# Altium schematic sheet SmartPKMJIG.SchDoc
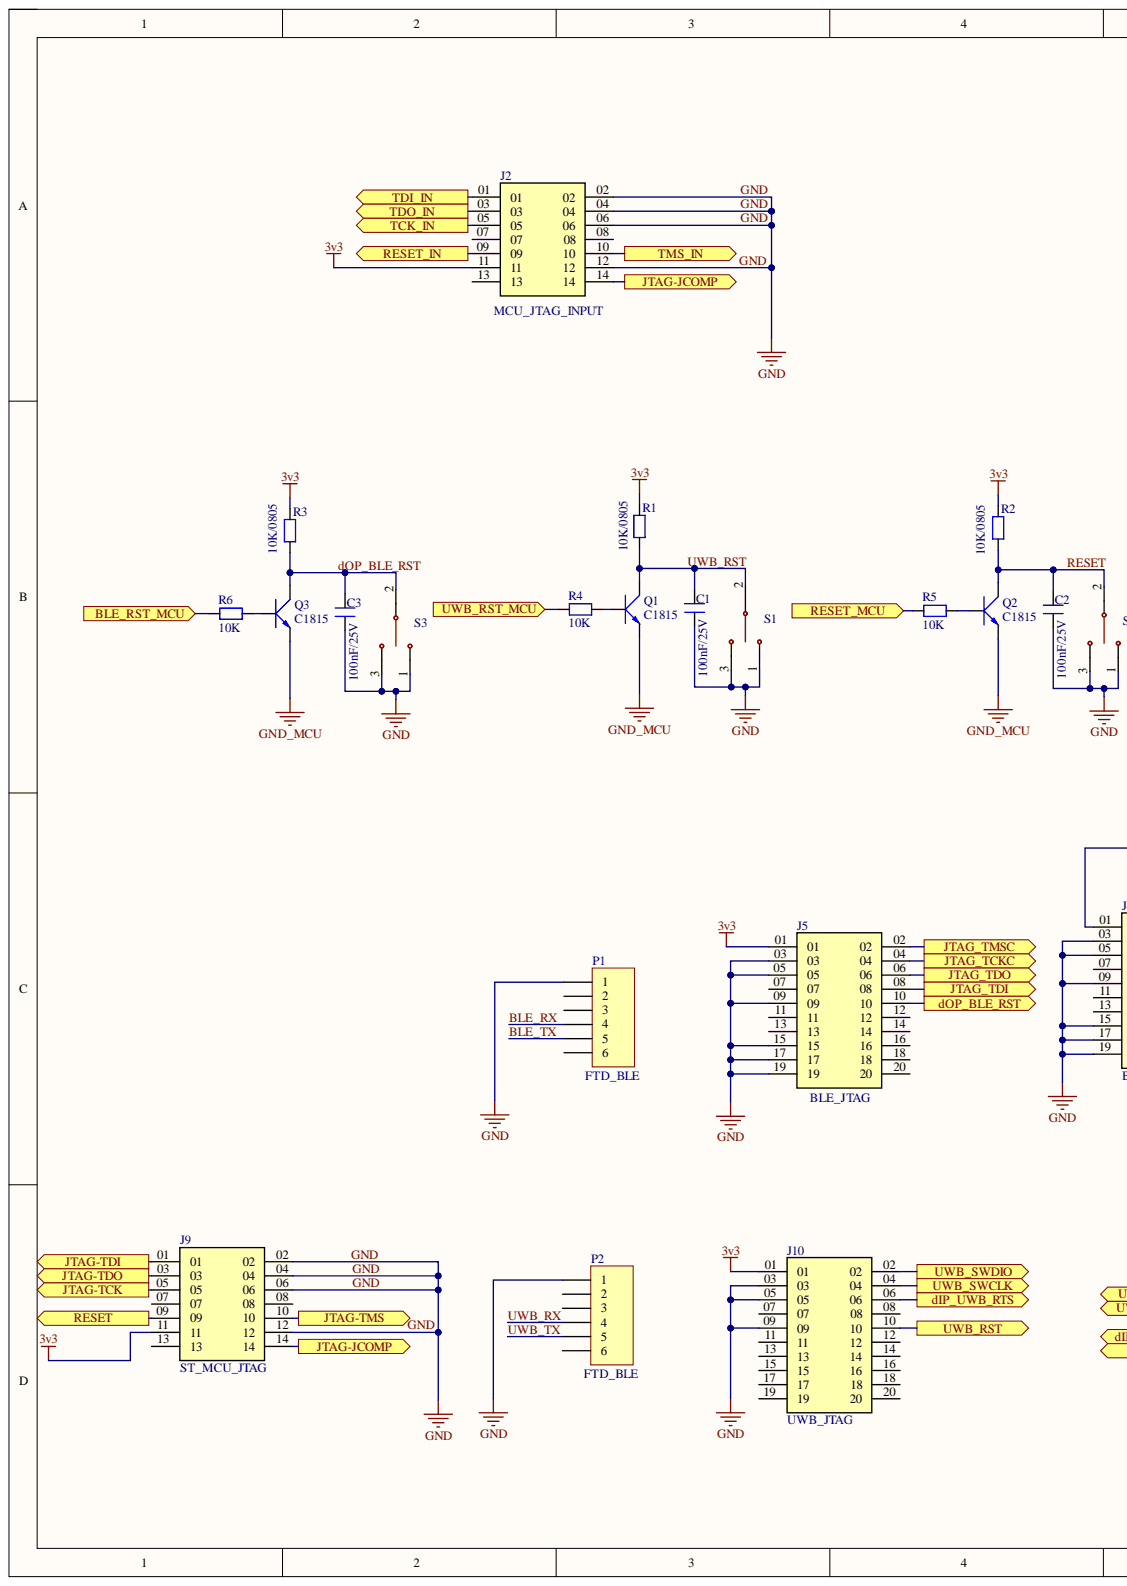
[diagram p0: SmartPKMJIG.SchDoc, left]
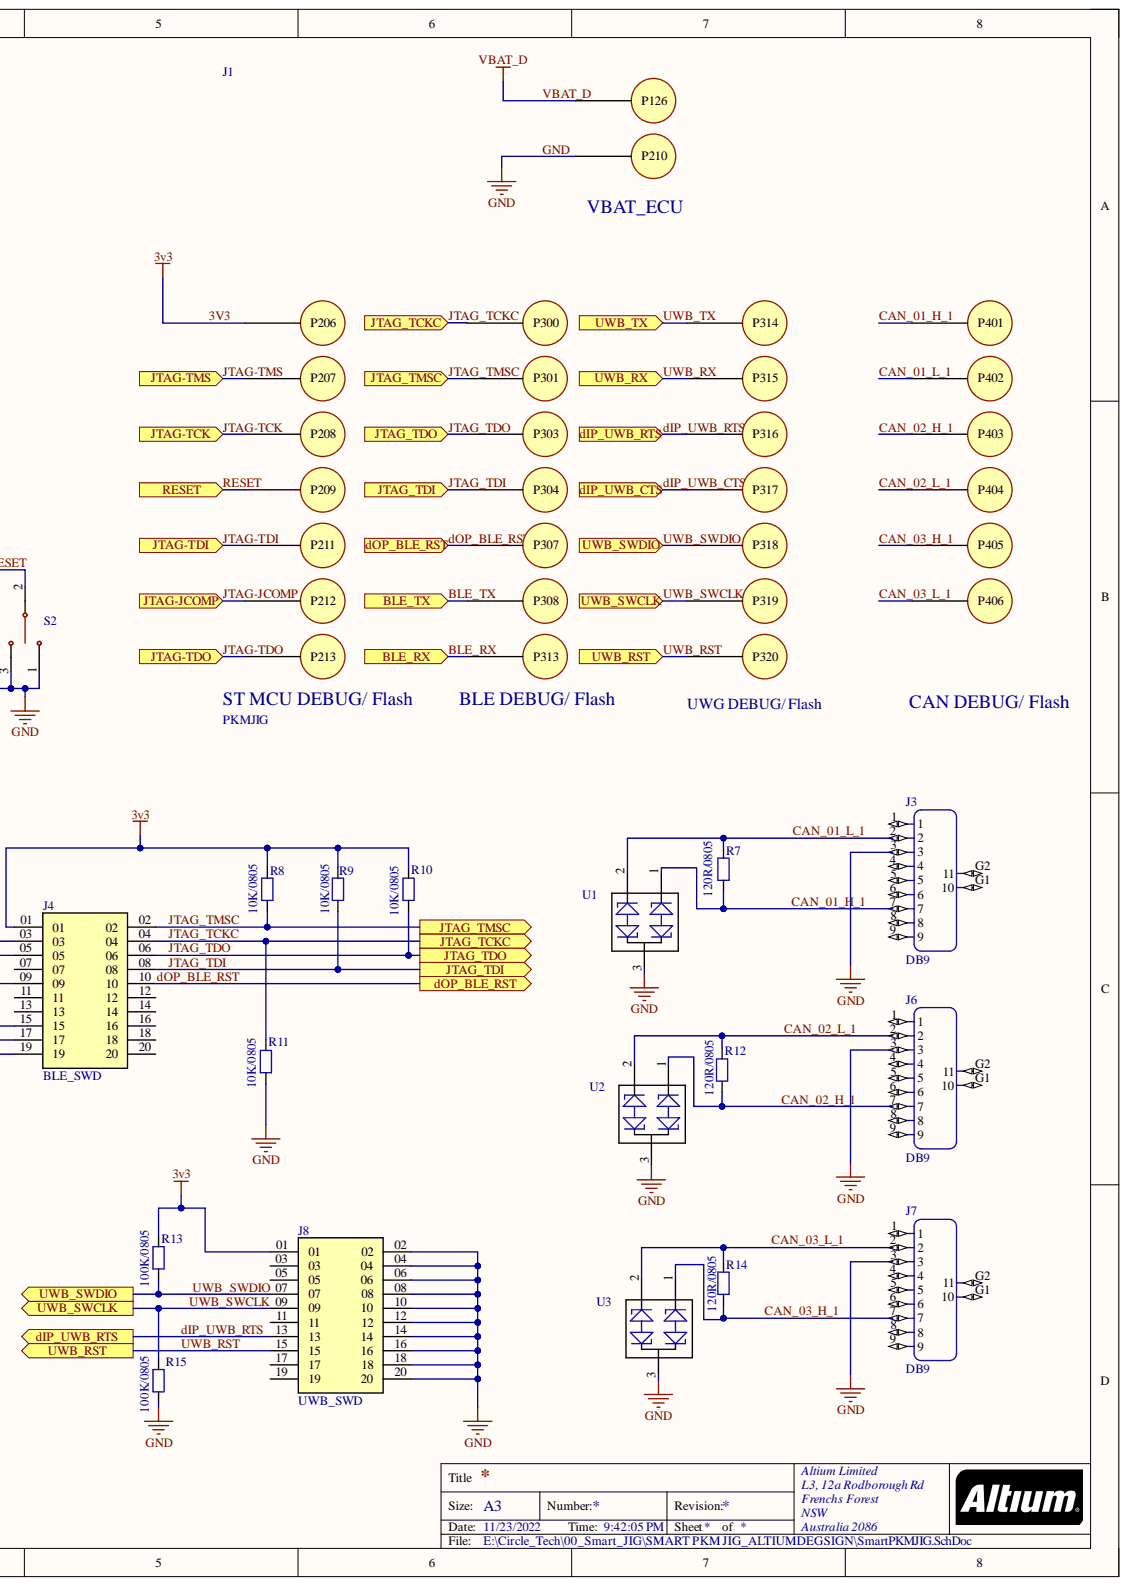
[diagram p1: SmartPKMJIG.SchDoc, right]

## Parts
C1  value 100nF/25V  [C0805]  (hidden comment: C0805 X5R ±10%)
C2  value 100nF/25V  [C0805]  (hidden comment: C0805 X5R ±10%)
C3  value 100nF/25V  [C0805]  (hidden comment: C0805 X5R ±10%)
J1  PKMJIG  [IVECO_JIG1]
J2  MCU_JTAG_INPUT  [IDC_14pin_2.54]
J3  DB9  [DB-9]
J4  BLE_SWD  [IDC_20p_2.54]
J5  BLE_JTAG  [IDC_20p_1.27]
J6  DB9  [DB-9]
J7  DB9  [DB-9]
J8  UWB_SWD  [IDC_20p_2.54]
J9  ST_MCU_JTAG  [IDC_14pin_2.54]
J10  UWB_JTAG  [IDC_20p_1.27]
P1  FTD_BLE  [HDR1X6H]
P2  FTD_BLE  [HDR1X6H]
Q1  C1815  [SOT23B]
Q2  C1815  [SOT23B]
Q3  C1815  [SOT23B]
R1  10K/0805  [R0805]
R2  10K/0805  [R0805]
R3  10K/0805  [R0805]
R4  value 10K  [R0603]  (hidden comment: Value)
R5  value 10K  [R0603]  (hidden comment: Value)
R6  value 10K  [R0603]  (hidden comment: Value)
R7  120R/0805  [R0805]
R8  10K/0805  [R0805]
R9  10K/0805  [R0805]
R10  10K/0805  [R0805]
R11  10K/0805  [R0805]
R12  120R/0805  [R0805]
R13  100K/0805  [R0805]
R14  120R/0805  [R0805]
R15  100K/0805  [R0805]
S1  100SP1T1B4M2QE  [Toggle_SW_DIP]  (value not drawn)
S2  100SP1T1B4M2QE  [Toggle_SW_DIP]  (value not drawn)
S3  100SP1T1B4M2QE  [Toggle_SW_DIP]  (value not drawn)
U1  Value  [SOT23]  (value not drawn)
U2  Value  [SOT23]  (value not drawn)
U3  Value  [SOT23]  (value not drawn)

## Ports
BLE_RST_MCU, BLE_RX, BLE_TX, dIP_UWB_CTS, dIP_UWB_RTS, dOP_BLE_RST, JTAG-JCOMP, JTAG-TCK, JTAG-TDI, JTAG-TDO, JTAG-TMS, JTAG_TCKC, JTAG_TDI, JTAG_TDO, JTAG_TMSC, RESET, RESET_IN, RESET_MCU, TCK_IN, TDI_IN, TDO_IN, TMS_IN, UWB_RST, UWB_RST_MCU, UWB_RX, UWB_SWCLK, UWB_SWDIO, UWB_TX

## Nets
GND_MCU: Q1.1 Q2.1 Q3.1
3V3: J1.P206 J2.11 J4.01 J5.01 J8.01 J9.11 J10.01 R1.2 R2.2 R3.2 R8.2 R9.2 R10.2 R13.2
BLE_RST_MCU: R6.2 ; port BLE_RST_MCU
BLE_RX: J1.P313 P1.4 ; port BLE_RX
BLE_TX: J1.P308 P1.5 ; port BLE_TX
CAN_01_H_1: J1.P401 J3.7 R7.1 U1.1
CAN_01_L_1: J1.P402 J3.2 R7.2 U1.2
CAN_02_H_1: J1.P403 J6.7 R12.1 U2.1
CAN_02_L_1: J1.P404 J6.2 R12.2 U2.2
CAN_03_H_1: J1.P405 J7.7 R14.1 U3.1
CAN_03_L_1: J1.P406 J7.2 R14.2 U3.2
dIP_UWB_CTS: J1.P317(dIP_UWB_CTS) ; port dIP_UWB_CTS
dIP_UWB_RTS: J1.P316(dIP_UWB_RTS) J8.13 J10.06 ; port dIP_UWB_RTS
dOP_BLE_RST: C3.2 J1.P307 J4.10 J5.10 Q3.2 R3.1 S3.2 ; port dOP_BLE_RST
GND: C1.1 C2.1 C3.1 J1.P210 J2.02 J2.04 J2.06 J2.12 J3.3 J4.03 J4.05 J4.09 J4.15 J4.17 J4.19 J5.03 J5.05 J5.09 J5.15 J5.17 J5.19 J6.3 J7.3 J8.02 J8.04 J8.06 J8.08 J8.10 J8.12 J8.14 J8.16 J8.18 J8.20 J9.02 J9.04 J9.06 J9.12 J10.03 J10.05 J10.09 P1.1 P2.1 R11.1 R15.1 S1.1 S1.3 S2.1 S2.3 S3.1 S3.3 U1.3 U2.3 U3.3
JTAG-JCOMP: J1.P212 J2.14 J9.14 ; port JTAG-JCOMP
JTAG-TCK: J1.P208 J9.05 ; port JTAG-TCK
JTAG-TDI: J1.P211 J9.01 ; port JTAG-TDI
JTAG-TDO: J1.P213 J9.03 ; port JTAG-TDO
JTAG-TMS: J1.P207 J9.10 ; port JTAG-TMS
JTAG_TCKC: J1.P300 J4.04 J5.04 R11.2 ; port JTAG_TCKC
JTAG_TDI: J1.P304 J4.08 J5.08 R9.1 ; port JTAG_TDI
JTAG_TDO: J1.P303 J4.06 J5.06 R10.1 ; port JTAG_TDO
JTAG_TMSC: J1.P301 J4.02 J5.02 R8.1 ; port JTAG_TMSC
RESET: C2.2 J1.P209 J9.09 Q2.2 R2.1 S2.2 ; port RESET
RESET_IN: J2.09 ; port RESET_IN
RESET_MCU: R5.2 ; port RESET_MCU
TCK_IN: J2.05 ; port TCK_IN
TDI_IN: J2.01 ; port TDI_IN
TDO_IN: J2.03 ; port TDO_IN
TMS_IN: J2.10 ; port TMS_IN
UWB_RST: C1.2 J1.P320 J8.15 J10.10 Q1.2 R1.1 S1.2 ; port UWB_RST
UWB_RST_MCU: R4.2 ; port UWB_RST_MCU
UWB_RX: J1.P315 P2.4 ; port UWB_RX
UWB_SWCLK: J1.P319(UWB_SWCLK) J8.09 J10.04 R15.2 ; port UWB_SWCLK
UWB_SWDIO: J1.P318(UWB_SWDIO) J8.07 J10.02 R13.1 ; port UWB_SWDIO
UWB_TX: J1.P314 P2.5 ; port UWB_TX
VBAT_D: J1.P126
NetQ1_3: Q1.3 R4.1
NetQ2_3: Q2.3 R5.1
NetQ3_3: Q3.3 R6.1
RESET_IN:
TCK_IN:
TDI_IN:
TDO_IN:
TMS_IN:

Not connected: J2 07 08 13; J3 1 4 5 6 8 9 G1 G2; J4 07 11 12 13 14 16 18 20; J5 07 11 12 13 14 16 18 20; J6 1 4 5 6 8 9 G1 G2; J7 1 4 5 6 8 9 G1 G2; J8 03 05 11 17 19; J9 07 08 13; J10 07 08 11 12 13 14 15 16 17 18 19 20; P1 2 3 6; P2 2 3 6

## Library data of the parts
C1-C3 = C0805 X5R ±10%: SMD Ceramic Capacitor 0805 X5R ±10%; Component Kind: Standard; Component Type: Passive; Package: C0805; Library Name: Daniel_Lib.IntLib
J1 = PKMJIG: JIGPKM; Component Kind: Standard; Library Name: PKM_JIG.SchLib
J2 J9 = IDC_14p_2.54: MF: Samtec; MP: EHF-110-01-L-D; Availability: Unavailable; Component Kind: Standard; Library Name: Connector.IntLib; Package: None; Price: None
J3 J6 J7 = DB9: SURGE SUPPRESSOR RS-232 DB9M/F; MF: Tripp Lite; Availability: Unavailable; Component Kind: Standard; Library Name: DB9.IntLib; MP: DB9; Package: None; Price: None; SnapEDA_Link: https://www.snapeda.com/parts/DB9/Tripp%20Lite/view-part/4219179/?ref=snap
J4 J5 J8 J10 = IDC_20p_1.27: MF: Samtec; MP: EHF-110-01-L-D; Availability: Unavailable; Component Kind: Standard; Library Name: Connector.IntLib; Package: None; Price: None
P1 P2 = Header 6H: Header, 6-Pin, Right Angle; Component Kind: Standard; LatestRevisionDate: 17-Jul-2002; LatestRevisionNote: Re-released for DXP Platform.; Library Name: Miscellaneous Connectors.IntLib; Signal Integrity: Connector; Publisher: Altium Limited
Q1-Q3 = C1815: NPN 600mW, ECB; Component Kind: Standard; Value: C1815; Library Name: syhaunguyen.IntLib
R1-R3 R7-R15 = R0805: Resistor SMD 0805 0.125W; Component Kind: Standard; Library Name: Daniel_Lib.IntLib
R4-R6 = R0603: SMD Resistor 0603 0.1W 1%; Manufacturer: Uniohm; Component Kind: Standard; Component Type: Passive; Package: R0603; Library Name: Daniel_Lib.IntLib
S1-S3 = 100SP1T1B4M2QE: Toggle Switch SPDT Through Hole; Availability: Unavailable; Component Kind: Standard; Library Name: Relay.IntLib; MP: 100SP1T1B4M2QE; Package: None; Price: None
U1-U3 = PESD_SOT23: Low capacitance double bidirectional ESD protection diodes  in SOT23; Component Kind: Standard; Library Name: Daniel_Lib.IntLib
